# Altium schematic sheet Sensor_Conn_Power_Supply.SchDoc
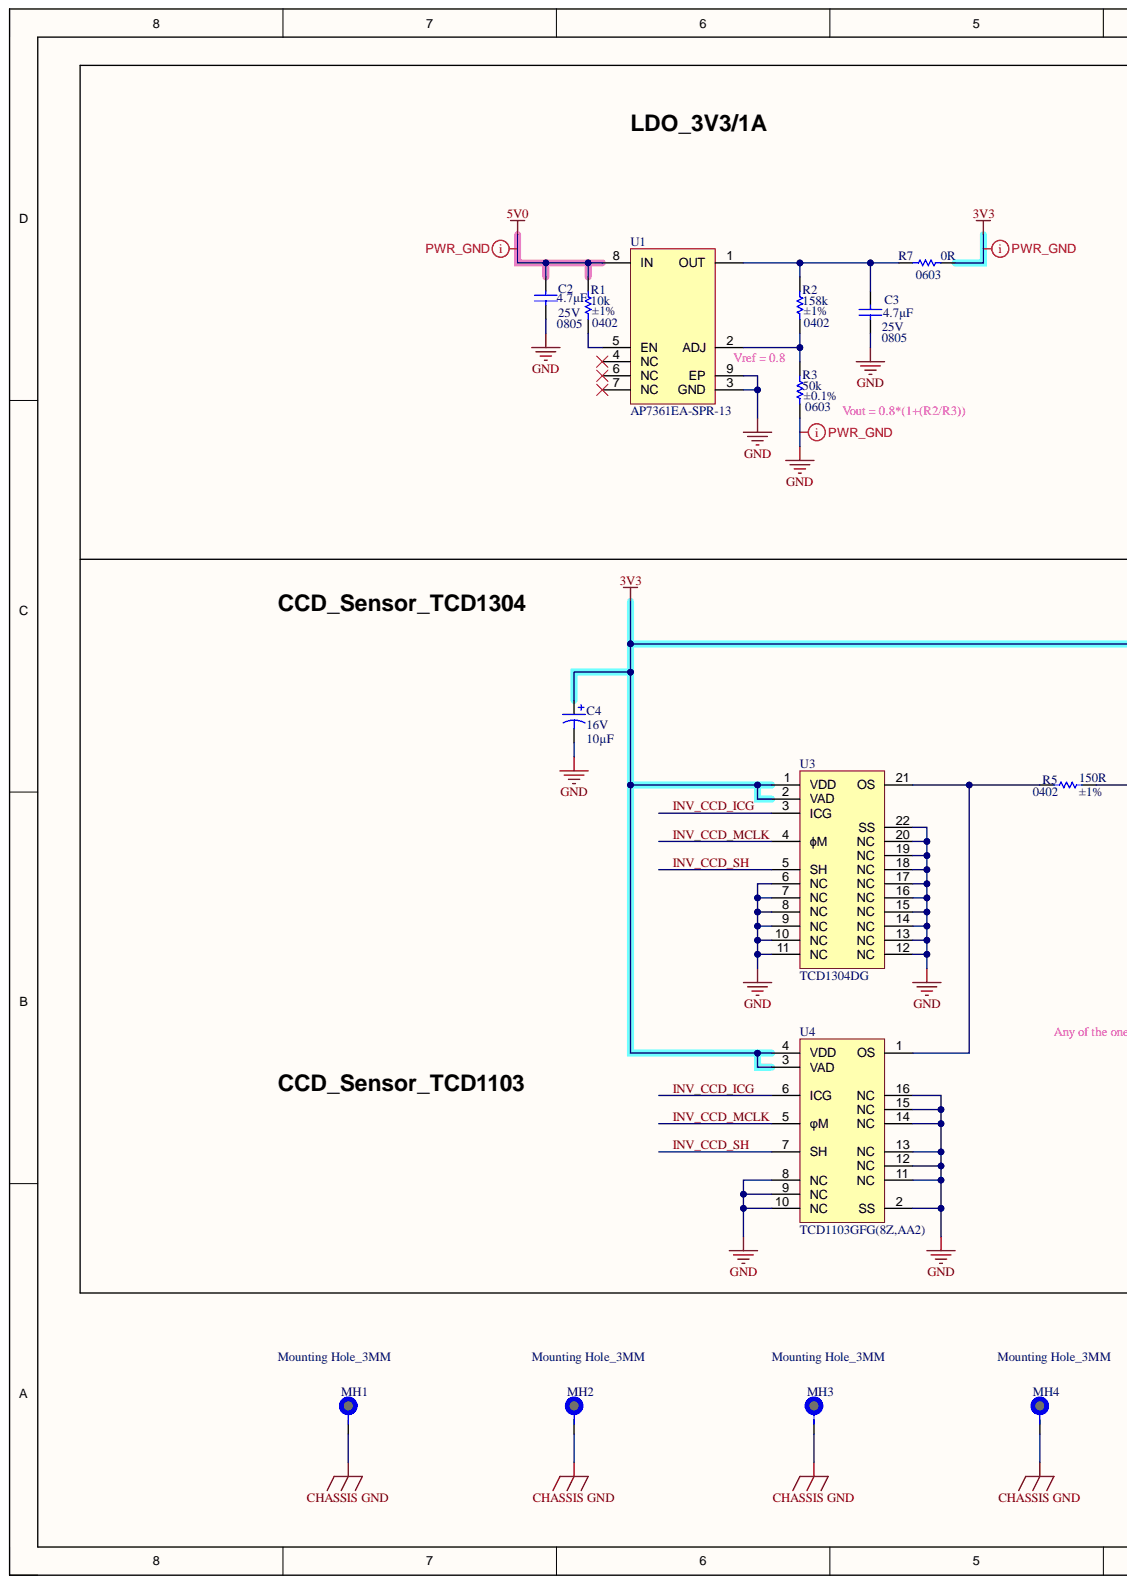
[diagram p0: Sensor_Conn_Power_Supply.SchDoc, left]
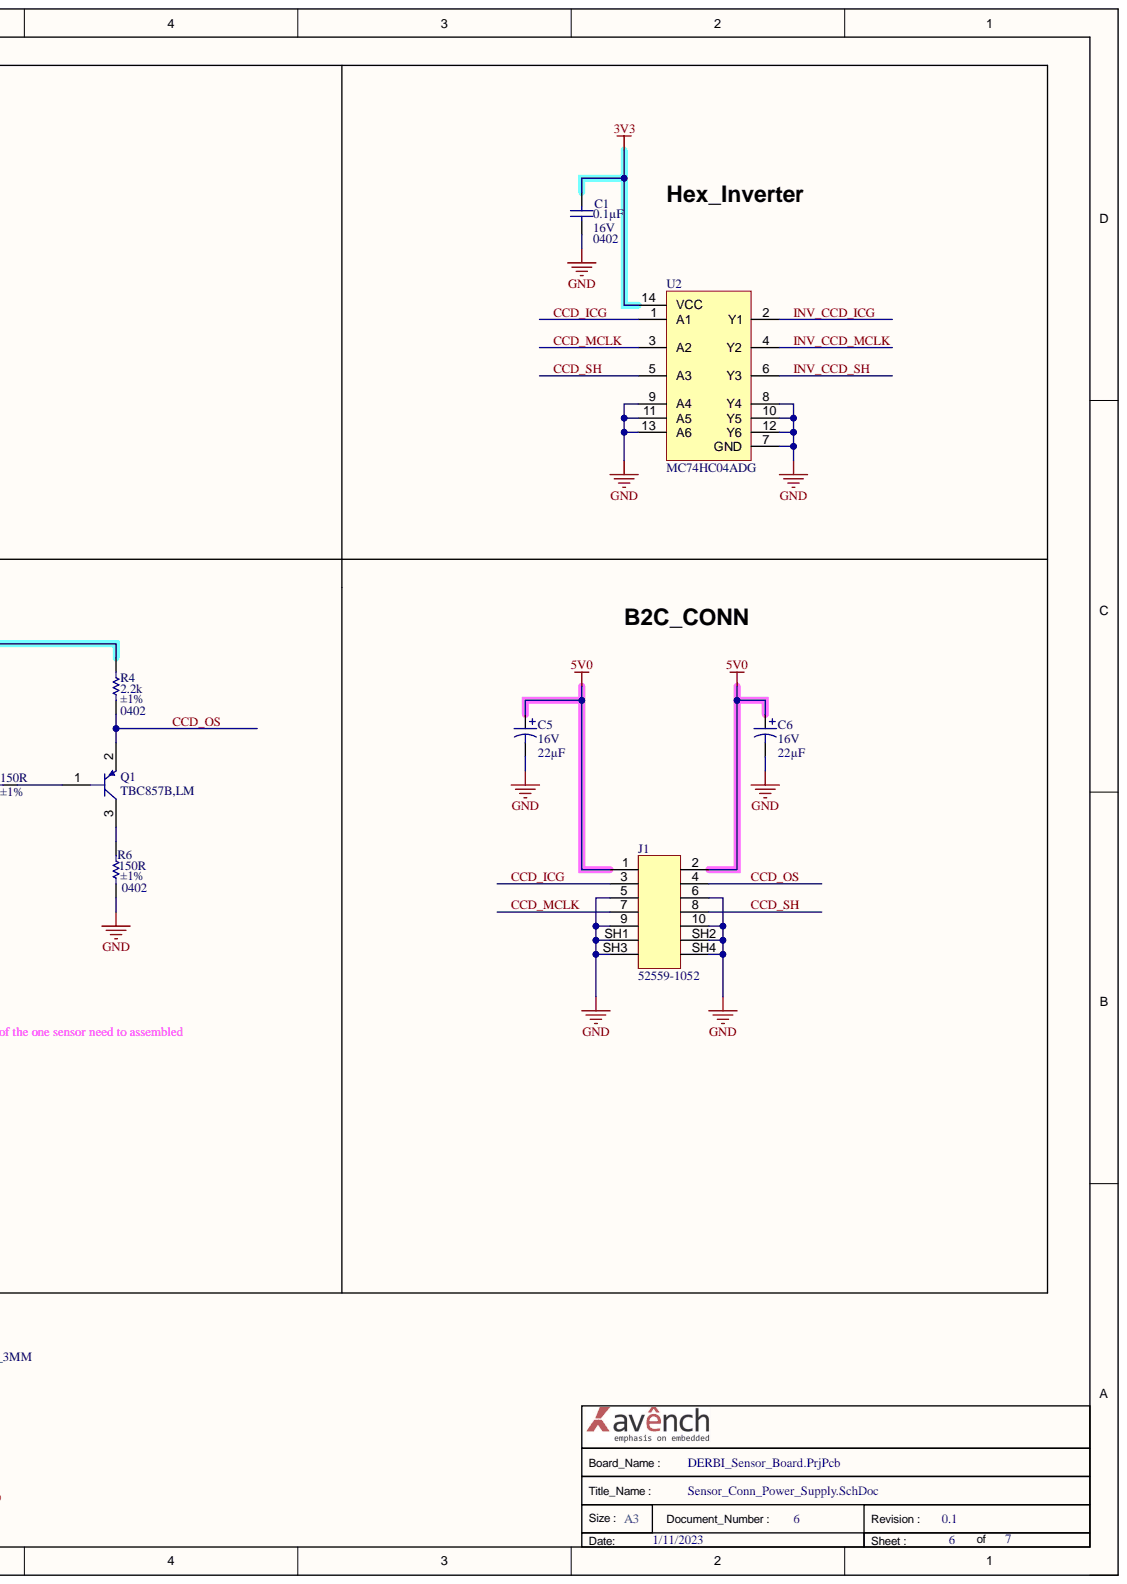
[diagram p1: Sensor_Conn_Power_Supply.SchDoc, right]

## Parts
C1  value 0.1µF  Package: 0402  Voltage: 16V  [CAP_0402]  (hidden comment: Cap 0.1uF)
C2  value 4.7µF  Package: 0805  Voltage: 25V  [CAP_0805]  (hidden comment: CAP_CER_4.7UF_25V_X5R_0805)
C3  value 4.7µF  Package: 0805  Voltage: 25V  [CAP_0805]  (hidden comment: CAP_CER_4.7UF_25V_X5R_0805)
C4  value 10µF  Voltage: 16V  [CAP_F981C106MSUAJ6]  (hidden comment: Cap 0.1uF)
C5  value 22µF  Voltage: 16V  [TNTCAPMP3216X16N]  (hidden comment: Cap 0.1uF)
C6  value 22µF  Voltage: 16V  [TNTCAPMP3216X16N]  (hidden comment: Cap 0.1uF)
J1  value 52559-1052  MPN: 52559-1052  [CONN_10Pos_  52559-1052]  (hidden comment: CONN_FFC_VERT_10POS_0.50MM_SMD)
MH1  Mounting Hole_3MM  [PlatedMH_3MM]
MH2  Mounting Hole_3MM  [PlatedMH_3MM]
MH3  Mounting Hole_3MM  [PlatedMH_3MM]
MH4  Mounting Hole_3MM  [PlatedMH_3MM]
Q1  value TBC857B,LM  MPN: TBC857B,LM  [SOT23-3P96_237X112L42X44]  (hidden comment: TRANS_PNP_50V_0.15A_SOT23-3)
R1  value 10k  Package: 0402  Tolerance: ±1%  [RES_0402]  (hidden comment: RES_10K_OHM_1%_1/10W_0402)
R2  value 158k  Package: 0402  Tolerance: ±1%  [RES_0402]  (hidden comment: RES_SMD_158K_OHM_1%_1/10W_0402)
R3  value 50k  Package: 0603  Tolerance: ±0.1%  [RES_0603]  (hidden comment: RES_50K_OHM_0.1%_1/10W_0603)
R4  value 2.2k  Package: 0402  Tolerance: ±1%  [RES_0402]  (hidden comment: RES_SMD_2.2K_OHM_1%_1/10W_0402)
R5  value 150R  Package: 0402  Tolerance: ±1%  [RES_0402]  (hidden comment: RES_SMD_150_OHM_1%_1/10W_0402)
R6  value 150R  Package: 0402  Tolerance: ±1%  [RES_0402]  (hidden comment: RES_SMD_150_OHM_1%_1/10W_0402)
R7  value 0R  Package: 0603  [RES_0603]  (hidden comment: RES_0_OHM_JUMPER_1/10W_0603)
U1  value AP7361EA-SPR-13  MPN: AP7361EA-SPR-13  [SOIC127P650X163-8N]  (hidden comment: LDO_CMOS_HICURR_SO-8EP_T&R_2.5K)
U2  MPN: MC74HC04ADG  [SOIC127P600X175-14N]  (hidden comment: IC_INVERTER_6CH_1-INP_14SOIC)
U3  MPN: TCD1304DG  [DIP-22]  (hidden comment: CCD_LINEAR_IMAGE_SENSOR_DIP22)
U4  MPN: TCD1103GFG(8Z,AA2)  [TCD1103GFG]  (hidden comment: CCD_Image_Sensor_5.5µm x 64µm_16-LCC)

## Nets
3V3: C1.2 C4.1 R4.1 R7.1 U2.14(VCC) U3.1(VDD) U3.2(VAD) U4.3(VAD) U4.4(VDD)
5V0: C2.2 C5.1 C6.1 J1.1 J1.2 R1.1 U1.8(IN)
CHASSIS GND: MH1.1 MH2.1 MH3.1 MH4.1
GND: C1.1 C2.1 C3.1 C4.2 C5.2 C6.2 J1.5 J1.6 J1.9 J1.10 J1.SH1 J1.SH2 J1.SH3 J1.SH4 R3.2 R6.2 U1.3(GND) U1.9(EP) U2.7(GND) U2.8(Y4) U2.9(A4) U2.10(Y5) U2.11(A5) U2.12(Y6) U2.13(A6) U3.6(NC) U3.7(NC) U3.8(NC) U3.9(NC) U3.10(NC) U3.11(NC) U3.12(NC) U3.13(NC) U3.14(NC) U3.15(NC) U3.16(NC) U3.17(NC) U3.18(NC) U3.19(NC) U3.20(NC) U3.22(SS) U4.2(SS) U4.8(NC) U4.9(NC) U4.10(NC) U4.11(NC) U4.12(NC) U4.13(NC) U4.14(NC) U4.15(NC) U4.16(NC)
CCD_ICG: J1.3 U2.1(A1)
CCD_MCLK: J1.7 U2.3(A2)
CCD_OS: J1.4 Q1.2 R4.2
CCD_SH: J1.8 U2.5(A3)
INV_CCD_ICG: U2.2(Y1) U3.3(ICG) U4.6(ICG)
INV_CCD_MCLK: U2.4(Y2) U3.4(ɸM) U4.5(φM)
INV_CCD_SH: U2.6(Y3) U3.5(SH) U4.7(SH)
NetC3_2: C3.2 R2.1 R7.2 U1.1(OUT)
NetQ1_1: Q1.1 R5.1
NetQ1_3: Q1.3 R6.1
NetR1_2: R1.2 U1.5(EN)
NetR2_2: R2.2 R3.1 U1.2(ADJ)
NetR5_2: R5.2 U3.21(OS) U4.1(OS)

Not connected: U1 4(NC) 6(NC) 7(NC)

## Library data of the parts
C1 = CAP_CER_0.1UF_16V_X5R_0402: 0.1 µF ±10% 16V Ceramic Capacitor X5R 0402 (1005 Metric); Manufacturer: Taiyo Yuden; MPN: EMK105BJ104KV-F; Component Kind: Standard; Operating Temperature: -55°C ~ 85°C; Tolerance: ±10%; Library Name: DERBI.SchLib
C2 C3 = CAP_CER_4.7UF_25V_X5R_0805: 4.7 µF ±10% 25V Ceramic Capacitor X5R 0805 (2012 Metric); Manufacturer: Taiyo Yuden; MPN: TMK212ABJ475KG-T; Component Kind: Standard; Operating Temperature: -55°C ~ 85°C; Tolerance: ±10%; Library Name: DERBI.SchLib
C4 = CA_ TANT_10UF: 10 µF Molded Tantalum Capacitors 16 V 0805 (2012 Metric) 4Ohm @ 100kHz; Manufacturer: KYOCERA AVX; MPN: F981C106MSUAJ6; Tolerance: ±20%; Component Kind: Standard; Operating Temperature: -55°C ~ 125°C; Package: SMD; Library Name: DERBI_Sensor.SchLib
C5 C6 = CAP_TANT_22UF_20%_16V_1206: 22 µF Molded Tantalum Capacitors 16 V 1206 (3216 Metric) 3Ohm @ 100kHz; Manufacturer: KYOCERA AVX; MPN: F931C226MAA; Tolerance: ±20%; Component Kind: Standard; Operating Temperature: -55°C ~ 125°C; Package: SMD; Library Name: DERBI_Sensor.SchLib
J1 = CONN_FFC_VERT_10POS_0.50MM_SMD: 10 Position FFC, FPC Connector Contacts, Vertical - 1 Sided 0.020" (0.50mm) Surface Mount; Manufacturer: Molex; Component Kind: Standard; Operating Temperature: -40°C ~ 85°C; Type: SMD; Library Name: DERBI.SchLib
Q1 = TRANS_PNP_50V_0.15A_SOT23-3: Bipolar (BJT) Transistor PNP 50 V 150 mA 80MHz 320 mW Surface Mount SOT-23-3; Manufacturer: Toshiba Semiconductor and Storage; Component Kind: Standard; Operating Temperature: -55°C ~ 150°C; Package: SOT-23-3; Library Name: DERBI_Sensor.SchLib
R1 = RES_10K_OHM_1%_1/10W_0402: 10 kOhms ±1% 0.1W, 1/10W Chip Resistor 0402 (1005 Metric) Automotive AEC-Q200, Moisture Resistant Thick Film; Manufacturer: KOA Speer Electronics, Inc.; MPN: RK73H1ETTPD1002F; Temperature Coefficient: ±100ppm/°C; Component Kind: Standard; Operating Temperature: -55°C ~ 155°C; Library Name: DERBI.SchLib
R2 = RES_SMD_158K_OHM_1%_1/10W_0402: 158 kOhms ±1% 0.1W, 1/10W Chip Resistor 0402 (1005 Metric) Automotive AEC-Q200 Thick Film; Manufacturer: Panasonic Electronic Components; MPN: ERJ-2RKF1583X; Temperature Coefficient: ±100ppm/°C; Component Kind: Standard; Operating Temperature: -55°C ~ 155°C; Library Name: DERBI.SchLib
R3 = RES_50K_OHM_0.1%_1/10W_0603: 50 kOhms ±0.1% 0.1W, 1/10W Chip Resistor 0603 (1608 Metric) Thin Film; Manufacturer: YAGEO; MPN: RT0603BRD0750KL; Temperature Coefficient: ±25ppm/°C; Component Kind: Standard; Operating Temperature: -55°C ~ 155°C; Library Name: DERBI.SchLib
R4 = RES_SMD_2.2K_OHM_1%_1/10W_0402: 2.2 kOhms ±1% 0.1W, 1/10W Chip Resistor 0402 (1005 Metric) Automotive AEC-Q200 Thick Film; Manufacturer: Panasonic Electronic Components; MPN: ERJ-2RKF2201X; Temperature Coefficient: ±100ppm/°C; Component Kind: Standard; Operating Temperature: -55°C ~ 155°C; Library Name: DERBI.SchLib
R5 R6 = RES_SMD_150_OHM_1%_1/10W_0402: 150 Ohms ±1% 0.1W, 1/10W Chip Resistor 0402 (1005 Metric) Automotive AEC-Q200 Thick Film; Manufacturer: Panasonic Electronic Components; MPN: ERJ-2RKF1500X; Temperature Coefficient: ±100ppm/°C; Component Kind: Standard; Operating Temperature: -55°C ~ 155°C; Library Name: DERBI.SchLib
R7 = RES_0_OHM_JUMPER_1/10W_0603: 0 Ohms Jumper 0.1W, 1/10W Chip Resistor 0603 (1608 Metric) Moisture Resistant Thick Film; Manufacturer: YAGEO; MPN: RC0603FR-070RL; Component Kind: Standard; Operating Temperature: -55°C ~ 155°C; Tolerance: Jumper; Library Name: DERBI.SchLib
U1 = LDO_CMOS_HICURR_SO-8EP_T&R_2.5K: Linear Voltage Regulator IC Positive Adjustable 1 Output 1A 8-SO-EP; Manufacturer: Diodes Incorporated; Component Kind: Standard; Operating Temperature: -40°C ~ 85°C; Package: 8-SO-EPR; Library Name: DERBI.SchLib
U2 = IC_INVERTER_6CH_1-INP_14SOIC: Inverter IC 6 Channel 14-SOIC; Manufacturer: onsemi; Value: 74HC04; Component Kind: Standard; Operating Temperature: -40°C ~ 85°C; Package: 14-SOIC; Library Name: DERBI.SchLib
U3 = CCD_LINEAR_IMAGE_SENSOR_DIP22: Image Sensors CCD Linear Image Sensor - B/W- 3648x1; Manufacturer: Toshiba Semiconductor and Storage; Value: TCD1304; Component Kind: Standard; Operating Temperature: -25°C ~ 60°C; Package: T-CAPPDIP-22; Library Name: DERBI.SchLib
U4 = CCD_Image_Sensor_5.5µm x 64µm_16-LCC: CCD Image Sensor 5.5µm x 64µm 16-LCC; Manufacturer: Toshiba Semiconductor and Storage; Value: TCD1103; Component Kind: Standard; Operating Temperature: -25°C ~ 60°C; Package: 16-LCC; Library Name: DERBI.SchLib
MH1-MH4 = Mounting Hole_3MM: Component Kind: Standard; Library Name: Adapter_Board.SchLib
